# Altium schematic sheet Inductors.SchDoc
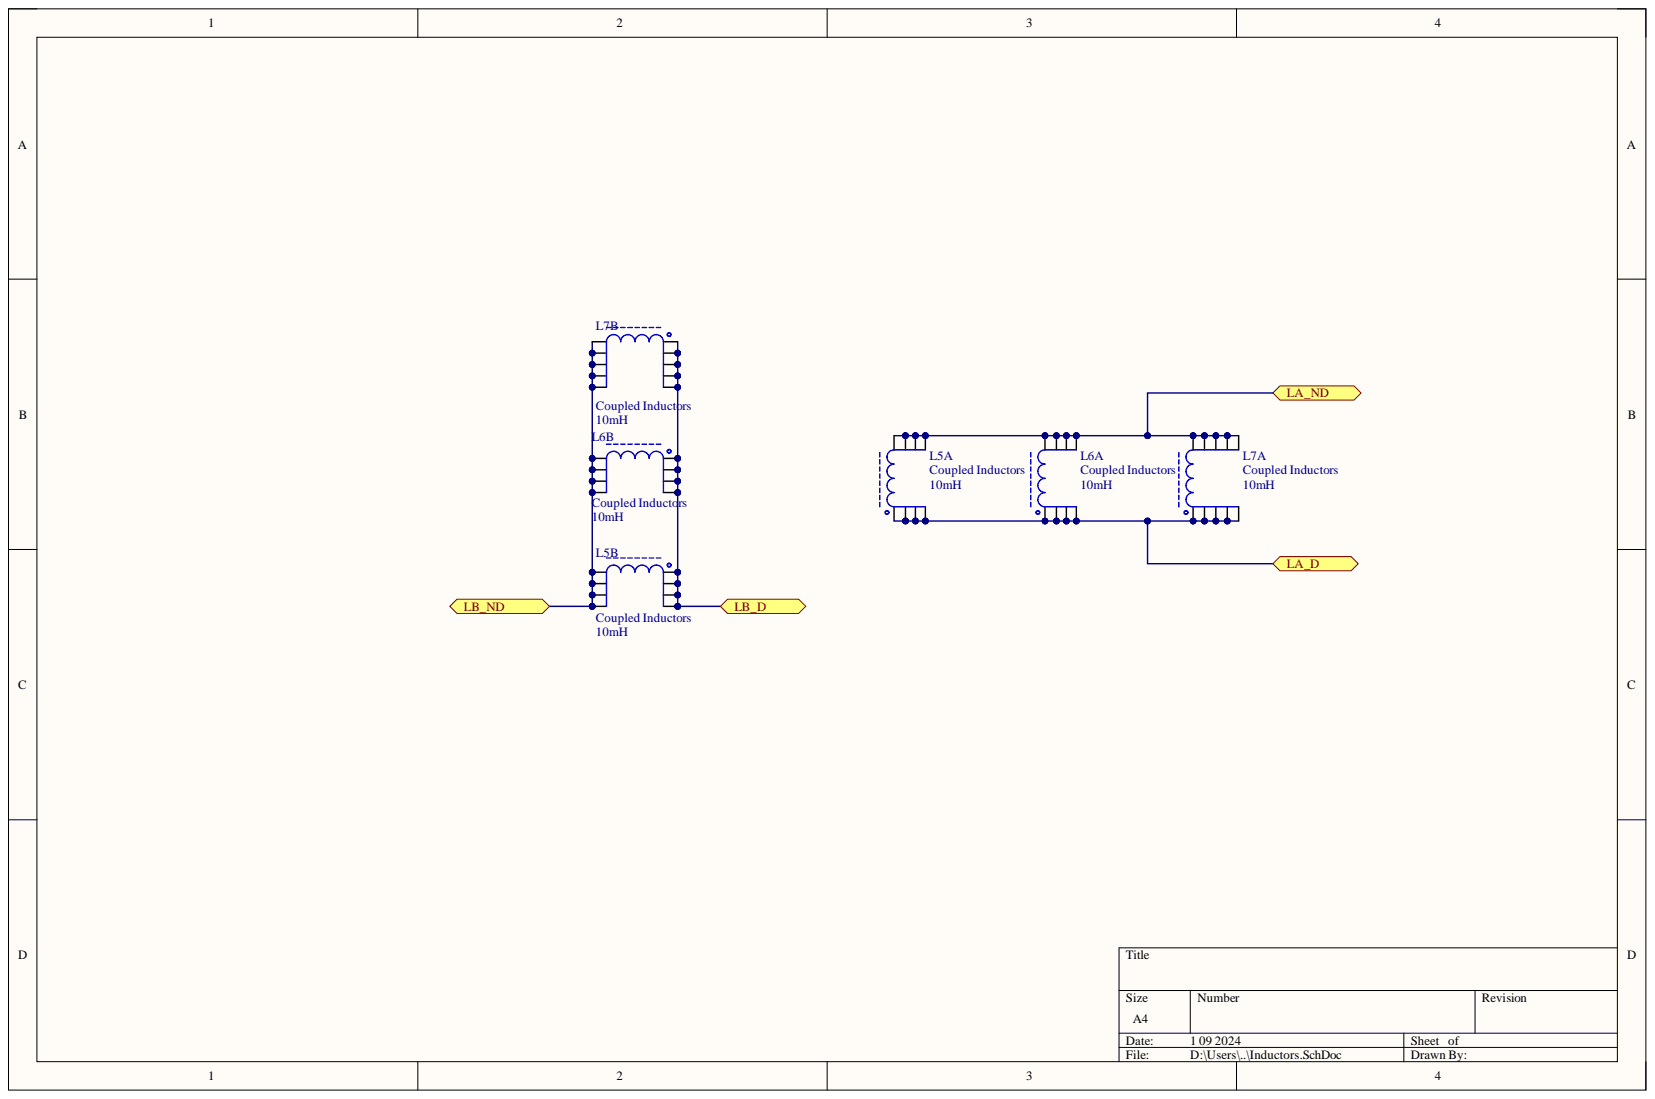
[diagram p0: Inductors.SchDoc]

## Parts
L5  Coupled Inductors  value 10mH  [Core_PM_62/49]  (parts A,B)
L6  Coupled Inductors  value 10mH  [Core_PM_74/59]  (parts A,B)
L7  Coupled Inductors  value 10mH  [Core_PM_87/70]  (parts A,B)

## Ports
LA_D, LA_ND, LB_D, LB_ND

## Nets
LA_D: L5.1 L5.2 L5.3 L5.4 L6.1 L6.2 L6.3 L6.4 L7.1 L7.2 L7.3 L7.4 L7.5 ; port LA_D
LA_ND: L5.13 L5.14 L5.15 L5.16 L6.15 L6.16 L6.17 L6.18 L7.16 L7.17 L7.18 L7.19 L7.20 ; port LA_ND
LB_D: L5.9 L5.10 L5.11 L5.12 L6.10 L6.11 L6.12 L6.13 L7.11 L7.12 L7.13 L7.14 L7.15 ; port LB_D
LB_ND: L5.5 L5.6 L5.7 L5.8 L6.6 L6.7 L6.8 L6.9 L7.6 L7.7 L7.8 L7.9 L7.10 ; port LB_ND

## Library data of the parts
L5 = Inductor 62/49: Coupled Inductors with Ferrite core and Air gap; Code_IEC: 1005; Code_JEITA: 0402; Component Kind: Standard; LatestRevisionDate: 29-May-2009; LatestRevisionNote: IPC-7351 Footprint Added.; Library Name: Inductor.SchLib; PackageDescription: Chip Inductor; PackageReference: 0402-A; Published: 8-Jun-2000; Signal Integrity: Inductor; Simulation: INDUCTOR; Publisher: Altium Limited
L6 = Inductor 74/59: Coupled Inductors with Ferrite core and Air gap; Code_IEC: 1005; Code_JEITA: 0402; Component Kind: Standard; LatestRevisionDate: 29-May-2009; LatestRevisionNote: IPC-7351 Footprint Added.; Library Name: Inductor.SchLib; PackageDescription: Chip Inductor; PackageReference: 0402-A; Published: 8-Jun-2000; Signal Integrity: Inductor; Simulation: INDUCTOR; Publisher: Altium Limited
L7 = Inductor 87/70: Coupled Inductors with Ferrite core and Air gap; Code_IEC: 1005; Code_JEITA: 0402; Component Kind: Standard; LatestRevisionDate: 29-May-2009; LatestRevisionNote: IPC-7351 Footprint Added.; Library Name: Inductor.SchLib; PackageDescription: Chip Inductor; PackageReference: 0402-A; Published: 8-Jun-2000; Signal Integrity: Inductor; Simulation: INDUCTOR; Publisher: Altium Limited
